# Altium schematic sheet Teensy Batt Board.SchDoc
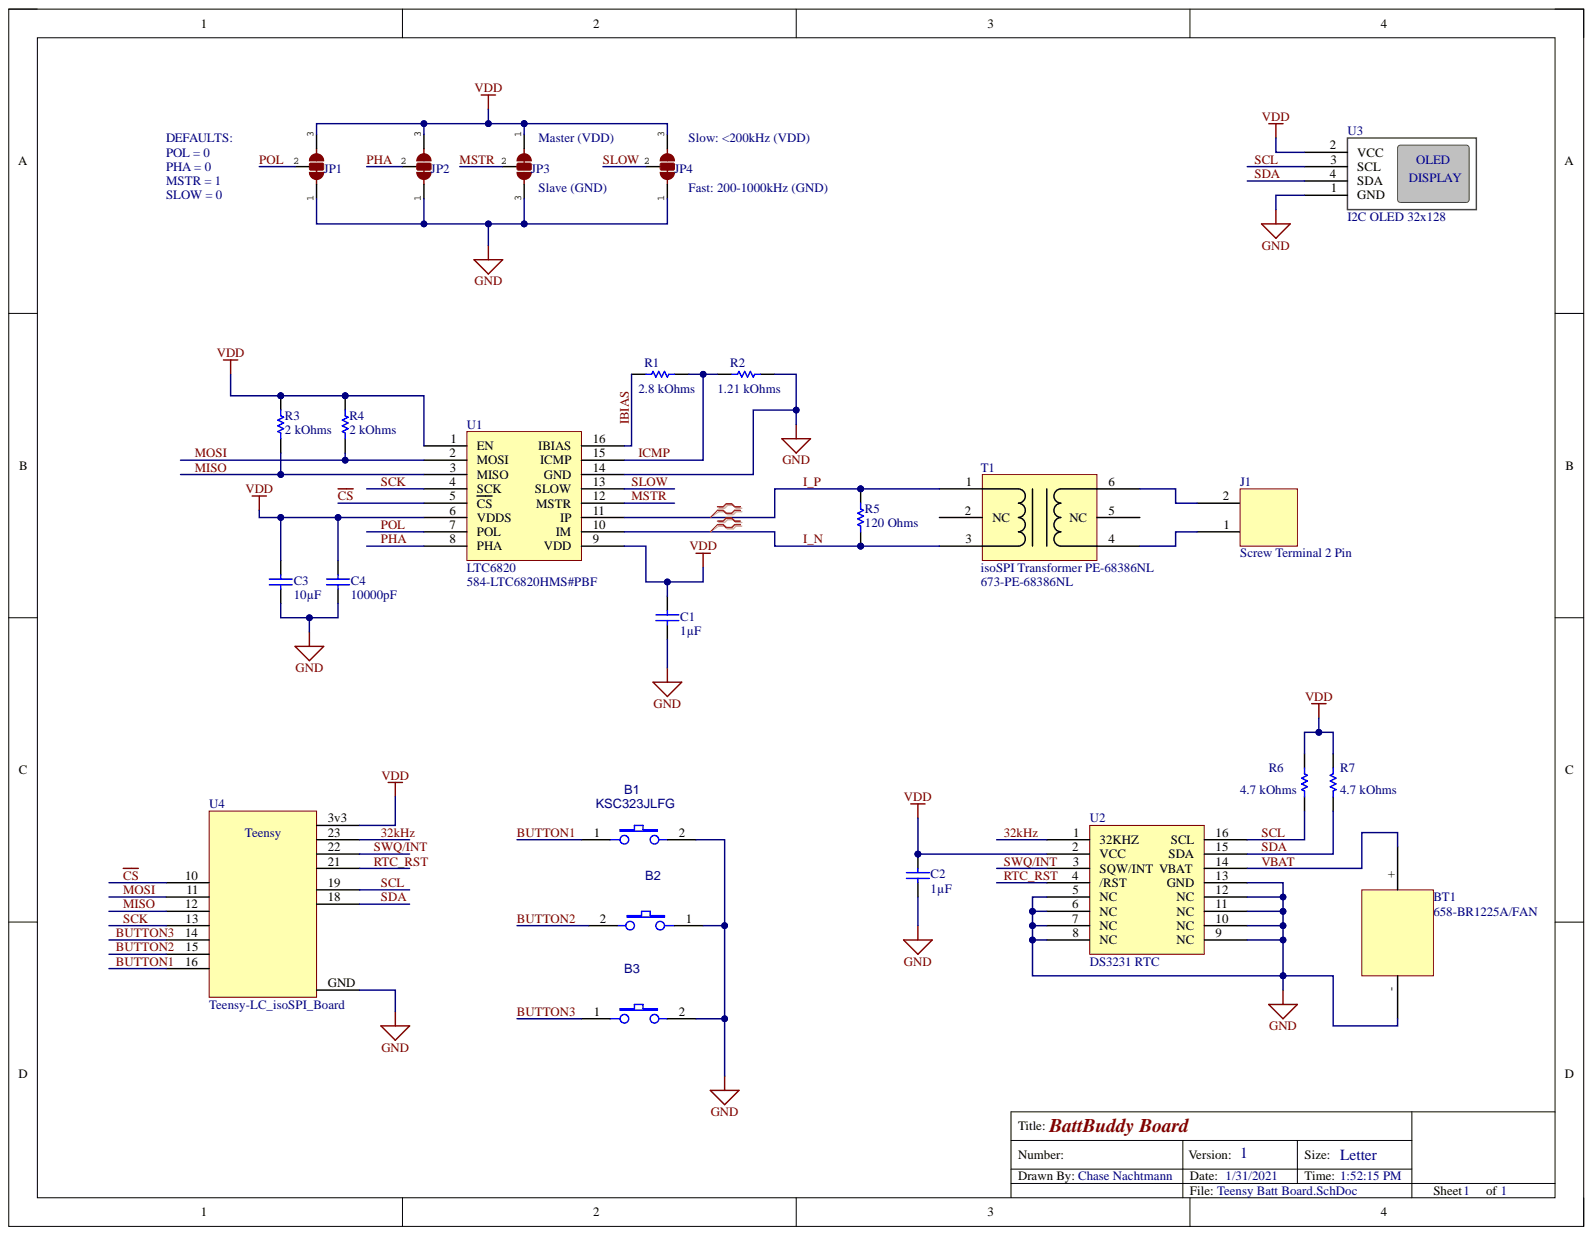
[diagram p0: Teensy Batt Board.SchDoc]

## Parts
B1  KSC323JLFG  [Pushbutton KSS223GLFG]
B2  KSC323JLFG  [Pushbutton KSS223GLFG]
B3  KSC323JLFG  [Pushbutton KSS223GLFG]
BT1  Supplier Part Number 1: 658-BR1225A/FAN  [Battery SMT Primary]  (hidden comment: Battery Primary)
C1  1µF  [CAPACITOR_0805]
C2  1µF  [CAPACITOR_0805]
C3  10µF  [CAPACITOR_0805]
C4  10000pF  [CAPACITOR_0805]
J1  Screw Terminal 2 Pin  [Screw Terminal 2Pos 5mm]
JP1  Jumper 2 Pad NC  [Jumper 3 Pad]  (value not drawn)
JP2  Jumper 2 Pad NC  [Jumper 3 Pad]  (value not drawn)
JP3  Jumper 2 Pad NC  [Jumper 3 Pad]  (value not drawn)
JP4  Jumper 2 Pad NC  [Jumper 3 Pad]  (value not drawn)
R1  value 2.8 kOhms  [RESISTOR_0603]  (hidden comment: Res1)
R2  value 1.21 kOhms  [RESISTOR_0603]  (hidden comment: Res1)
R3  value 2 kOhms  [RESISTOR_0603]  (hidden comment: Res1)
R4  value 2 kOhms  [RESISTOR_0603]  (hidden comment: Res1)
R5  value 120 Ohms  [RESISTOR_0603]  (hidden comment: Res1)
R6  value 4.7 kOhms  [RESISTOR_0603]  (hidden comment: Res1)
R7  value 4.7 kOhms  [RESISTOR_0603]  (hidden comment: Res1)
T1  isoSPI Transformer PE-68386NL  Supplier Part Number 1: 673-PE-68386NL  [PE-68386NL]
U1  LTC6820  Supplier Part Number 1: 584-LTC6820HMS#PBF  [LTC6820 MSOP16]
U2  DS3231 RTC  [DS3231 RTC SOIC127P1030X265-16N]
U3  I2C OLED 32x128  [OLED 32x128]
U4  Teensy-LC_isoSPI_Board  [Teensy-3.5_isoSPI_board]

## Nets
GND: B1.2 B2.1 B3.2 BT1.- C1.1 C2.1 C3.1 C4.1 JP1.1 JP2.1 JP3.3 JP4.1 R2.1 U1.14(GND) U2.5(NC) U2.6(NC) U2.7(NC) U2.8(NC) U2.9(NC) U2.10(NC) U2.11(NC) U2.12(NC) U2.13(GND) U3.1(GND) U4.GND
VDD: C1.2 C2.2 C3.2 C4.2 JP1.3 JP2.3 JP3.1 JP4.3 R3.2 R4.2 R6.2 R7.2 U1.1(EN) U1.6(VDDS) U1.9(VDD) U2.2(VCC) U3.2(VCC) U4.3v3
32kHz: U2.1(32KHZ) U4.23
BUTTON1: B1.1 U4.16
BUTTON2: B2.2 U4.15
BUTTON3: B3.1 U4.14
~{CS}: U1.5(CS) U4.10
I_N: R5.1 T1.3 U1.10(IM)
I_P: R5.2 T1.1 U1.11(IP)
IBIAS: R1.1 U1.16(IBIAS)
ICMP: R1.2 R2.2 U1.15(ICMP)
MISO: R3.1 U1.3(MISO) U4.12
MOSI: R4.1 U1.2(MOSI) U4.11
MSTR: JP3.2 U1.12(MSTR)
PHA: JP2.2 U1.8(PHA)
POL: JP1.2 U1.7(POL)
RTC_RST: U2.4(/RST) U4.21
SCK: U1.4(SCK) U4.13
SCL: R6.1 U2.16(SCL) U3.3(SCL) U4.19
SDA: R7.1 U2.15(SDA) U3.4(SDA) U4.18
SLOW: JP4.2 U1.13(SLOW)
SWQ/INT: U2.3(SQW/INT) U4.22
VBAT: BT1.+ U2.14(VBAT)
NetJ1_1: J1.1 T1.4
NetJ1_2: J1.2 T1.6

Not connected: T1 2(NC) 5(NC)

## Library data of the parts
B1-B3 = SW-PUSH-KSS223GLFG: SWITCH TACTILE SPST-NO 0.01A 50V; Manufacturer: ITT C&K; Actuator Length: 0.8mm; Contact Current Rating: 10mA; Illumination Type: Non-Illuminated; Max Voltage Rating (DC): 32V; Mechanical Life: 100000Cycles; Operating Force: 2N; Power Rating: 200mW; Ratings: IP40; Switch Function: Off-Mom; Switch Travel: 0.3mm; Throw Configuration: SPST; Case/Package: SMD/SMT; Category: Tactile Switches; Component Kind: Standard; ComponentLink1Description: Datasheet; ComponentLink1URL: https://www.mouser.com/datasheet/2/60/kss-1841788.pdf; ComponentLink2Description: https://datasheet.ciiva.com/2901/kss-2901088.pdf?src-supplier=Digi-Key; ComponentLink2URL: https://datasheet.ciiva.com/2901/kss-2901088.pdf?src-supplier=Digi-Key; ComponentLink3Description: https://datasheet.ciiva.com/2901/kss-2901089.pdf?src-supplier=Digi-Key; ComponentLink3URL: https://datasheet.ciiva.com/2901/kss-2901089.pdf?src-supplier=Digi-Key; ComponentLink4Description: https://datasheet.ciiva.com/2901/kss-2901090.pdf?src-supplier=Digi-Key; ComponentLink4URL: https://datasheet.ciiva.com/2901/kss-2901090.pdf?src-supplier=Digi-Key; ComponentLink5Description: https://datasheet.ciiva.com/16496/kss-16496167.pdf?src-supplier=Future+Electronics; ComponentLink5URL: https://datasheet.ciiva.com/16496/kss-16496167.pdf?src-supplier=Future+Electronics; Contact Material: Gold; Contact Plating: Gold; Current Rating: 10mA; Depth: 4.4mm; Lead Free: Lead Free; Length: 7mm; Manufacturer Part Number: KSS223GLFG; Max Current Rating: 10mA; Max Operating Temperature: 125°C; Min Operating Temperature: -40°C; Mount: Surface Mount; Orientation: Right Angle; Package Quantity: 3000; Packaging: Tape and Reel; Pricing 1: 1=0.77000, 10=0.73700, 50=0.68800, 100=0.61600, 1000=0.44200, 10000=0.44200 (USD); Radiation Hardening: No; RoHS: Yes; RoHS Compliant: Yes; Series: KSS; Stock 1: 2441; Supplier 1: Mouser; Supplier Part Number 1: 611-KSS223GLFG; Termination: Gull Wing; Voltage Rating (DC): 32V; Width: 3.5mm; Library Name: cnachtmann.SchLib
BT1 = Battery Primary: BATTERY LITHIUM 3V COIN 12.5MM; Manufacturer: Panasonic BSG; Manufacturer Part Number: BR1225A/FAN; Capacity: 0.048ah; Number of Cells: 1; Battery Type: PRIMARY; Category: Connectors; Chemistry/Technology: Lithium; Component Kind: Standard; ComponentLink1Description: http://industrial.panasonic.com/www-data/pdf2/AAA4000/AAA4000CE35.pdf?src-supplier=Avnet+Asia; ComponentLink1URL: http://industrial.panasonic.com/www-data/pdf2/AAA4000/AAA4000CE35.pdf?src-supplier=Avnet+Asia; ComponentLink2Description: http://industrial.panasonic.com/www-data/pdf2/AAA4000/AAA4000CE35.pdf?src-supplier=Avnet; ComponentLink2URL: http://industrial.panasonic.com/www-data/pdf2/AAA4000/AAA4000CE35.pdf?src-supplier=Avnet; Contact Plating: Tin; Height: 2.5mm; Max Operating Temperature: 125°C; Min Operating Temperature: -40°C; Mount: Through Hole; Pricing 1: 1=1.87000, 10=1.56000, 50=1.37000, 100=1.30000, 1000=1.09000, 10000=1.00000 (USD); RoHS: Yes; RoHS Compliant: Yes; Stock 1: 7371; Supplier 1: Mouser; Voltage: 3V; Voltage Rating: 3V; Library Name: cnachtmann.SchLib
C1 C2 = C0805C105K8RACTU: CAP CER 1UF 10V X7R 0805; Manufacturer: KEMET; Component: Standard; Database Table Name: Kemet_0805_Capacitors; Tolerance: ±10%; Applications: General Purpose; Component Kind: Standard; ComponentLink1Description: Datasheet; ComponentLink1URL: https://api.kemet.com/component-edge/download/datasheet/C0805C105K8RACTU.pdf; Digi-Key Part Number: 399-1172-1-ND; Features: Low ESL; Library Name: part_db.DbLib; Manufacturer Part Number: C0805C105K8RACTU; Mounting Type: Surface Mount, MLCC; Operating Temperature: -55°C ~ 125°C; Package / Case: 0805 (2012 Metric); Part Number: C0805C105K8RACTU; Ratings: -; Series: C; Supplier: Digi-Key; Temperature Coefficient: X7R; Value: 1µF; Voltage - Rated: 10V
C3 = C0805X106M9RAC7800: CAP CER 10UF 6.3V X7R 0805; Manufacturer: KEMET; Component: Standard; Database Table Name: Kemet_0805_Capacitors; Tolerance: ±20%; Applications: Boardflex Sensitive; Component Kind: Standard; ComponentLink1Description: Datasheet; ComponentLink1URL: https://api.kemet.com/component-edge/download/datasheet/C0805X106M9RAC7800.pdf; Digi-Key Part Number: 399-15700-1-ND; Features: Soft Termination; Library Name: part_db.DbLib; Manufacturer Part Number: C0805X106M9RAC7800; Mounting Type: Surface Mount, MLCC; Operating Temperature: -55°C ~ 125°C; Package / Case: 0805 (2012 Metric); Part Number: C0805X106M9RAC7800; Ratings: -; Series: FT-CAP; Supplier: Digi-Key; Temperature Coefficient: X7R; Value: 10µF; Voltage - Rated: 6.3V
C4 = C0805C103K8RAC7800: CAP CER 10000PF 10V X7R 0805; Manufacturer: KEMET; Component: Standard; Database Table Name: Kemet_0805_Capacitors; Tolerance: ±10%; Applications: General Purpose; Component Kind: Standard; ComponentLink1Description: Datasheet; ComponentLink1URL: https://api.kemet.com/component-edge/download/datasheet/C0805C103K8RACTU.pdf; Digi-Key Part Number: 399-14549-1-ND; Features: Low ESL; Library Name: part_db.DbLib; Manufacturer Part Number: C0805C103K8RAC7800; Mounting Type: Surface Mount, MLCC; Operating Temperature: -55°C ~ 125°C; Package / Case: 0805 (2012 Metric); Part Number: C0805C103K8RAC7800; Ratings: -; Series: C; Supplier: Digi-Key; Temperature Coefficient: X7R; Value: 10000pF; Voltage - Rated: 10V
JP1-JP4 = Jumper 3 Pad: 3 Pad Jumper Connection (P1/2 Normally Connected by Trace); Component Kind: Net Tie; Library Name: cnachtmann.SchLib
R1 = ERA-3AEB2801V: RES SMD 2.8K OHM 0.1% 1/10W 0603; Manufacturer: Panasonic Electronic Components; Component: Standard; Database Table Name: Panasonic_AEC-Q200_0603_Resistors; Power (Watts): 0.1W, 1/10W; Temperature Coefficient: ±25ppm/°C; Component Kind: Standard; ComponentLink1Description: Datasheet; ComponentLink1URL: https://industrial.panasonic.com/cdbs/www-data/pdf/RDM0000/AOA0000C307.pdf; Composition: Thin Film; Digi-Key Part Number: P2.8KDBCT-ND; Features: Automotive AEC-Q200; Library Name: part_db.DbLib; Manufacturer Part Number: ERA-3AEB2801V; Operating Temperature: -55°C ~ 155°C; Package / Case: 0603 (1608 Metric); Part Number: ERA-3AEB2801V; Series: ERA-3A; Supplier: Digi-Key; Supplier Device Package: 603; Tolerance: ±0.1%
R2 = ERA-3AEB1211V: RES SMD 1.21KOHM 0.1% 1/10W 0603; Manufacturer: Panasonic Electronic Components; Component: Standard; Database Table Name: Panasonic_AEC-Q200_0603_Resistors; Power (Watts): 0.1W, 1/10W; Temperature Coefficient: ±25ppm/°C; Component Kind: Standard; ComponentLink1Description: Datasheet; ComponentLink1URL: https://industrial.panasonic.com/cdbs/www-data/pdf/RDM0000/AOA0000C307.pdf; Composition: Thin Film; Digi-Key Part Number: P1.21KDBCT-ND; Features: Automotive AEC-Q200; Library Name: part_db.DbLib; Manufacturer Part Number: ERA-3AEB1211V; Operating Temperature: -55°C ~ 155°C; Package / Case: 0603 (1608 Metric); Part Number: ERA-3AEB1211V; Series: ERA-3A; Supplier: Digi-Key; Supplier Device Package: 603; Tolerance: ±0.1%
R3 R4 = ERA-3AEB202V: RES SMD 2K OHM 0.1% 1/10W 0603; Manufacturer: Panasonic Electronic Components; Component: Standard; Database Table Name: Panasonic_AEC-Q200_0603_Resistors; Power (Watts): 0.1W, 1/10W; Temperature Coefficient: ±25ppm/°C; Component Kind: Standard; ComponentLink1Description: Datasheet; ComponentLink1URL: https://industrial.panasonic.com/cdbs/www-data/pdf/RDM0000/AOA0000C307.pdf; Composition: Thin Film; Digi-Key Part Number: P2.0KDBCT-ND; Features: Automotive AEC-Q200; Library Name: part_db.DbLib; Manufacturer Part Number: ERA-3AEB202V; Operating Temperature: -55°C ~ 155°C; Package / Case: 0603 (1608 Metric); Part Number: ERA-3AEB202V; Series: ERA-3A; Supplier: Digi-Key; Supplier Device Package: 603; Tolerance: ±0.1%
R5 = ERA-3AEB121V: RES SMD 120 OHM 0.1% 1/10W 0603; Manufacturer: Panasonic Electronic Components; Component: Standard; Database Table Name: Panasonic_AEC-Q200_0603_Resistors; Power (Watts): 0.1W, 1/10W; Temperature Coefficient: ±25ppm/°C; Component Kind: Standard; ComponentLink1Description: Datasheet; ComponentLink1URL: https://industrial.panasonic.com/cdbs/www-data/pdf/RDM0000/AOA0000C307.pdf; Composition: Thin Film; Digi-Key Part Number: P120DBCT-ND; Features: Automotive AEC-Q200; Library Name: part_db.DbLib; Manufacturer Part Number: ERA-3AEB121V; Operating Temperature: -55°C ~ 155°C; Package / Case: 0603 (1608 Metric); Part Number: ERA-3AEB121V; Series: ERA-3A; Supplier: Digi-Key; Supplier Device Package: 603; Tolerance: ±0.1%
R6 R7 = ERJ-3EKF4701V: RES SMD 4.7K OHM 1% 1/10W 0603; Manufacturer: Panasonic Electronic Components; Component: Standard; Database Table Name: Panasonic_AEC-Q200_0603_Resistors; Power (Watts): 0.1W, 1/10W; Temperature Coefficient: ±100ppm/°C; Component Kind: Standard; ComponentLink1Description: Datasheet; ComponentLink1URL: https://industrial.panasonic.com/cdbs/www-data/pdf/RDA0000/AOA0000C304.pdf; Composition: Thick Film; Digi-Key Part Number: P4.70KHCT-ND; Features: Automotive AEC-Q200; Library Name: part_db.DbLib; Manufacturer Part Number: ERJ-3EKF4701V; Operating Temperature: -55°C ~ 155°C; Package / Case: 0603 (1608 Metric); Part Number: ERJ-3EKF4701V; Series: ERJ; Supplier: Digi-Key; Supplier Device Package: 603; Tolerance: ±1%
T1 = isoSPI Transformer PE-68386NL: Pulse Transformer 1:1 1500VDC 0.6Ohm Prim. DCR 0.6Ohm Sec. DCR 9.7Vus Surface Mount; DC Resistance (DCR): 600mR; Inductance: 785uH; Isolation Voltage: 1.5kV; Shielding: Unshielded; Category: Transformers; Component Kind: Standard; ComponentLink1Description: Datasheet; ComponentLink1URL: https://www.mouser.com/datasheet/2/336/SPM2007_61-1526932.pdf; ComponentLink2Description: https://datasheet.ciiva.com/2939/+spm2007-61-2939892.pdf?src-supplier=Digi-Key; ComponentLink2URL: https://datasheet.ciiva.com/2939/+spm2007-61-2939892.pdf?src-supplier=Digi-Key; Depth: 8.64mm; Height: 2.5mm; Length: 8.6mm; Manufacturer: Pulse; Manufacturer Part Number: PE-68386NL; Max Operating Temperature: 130°C; Min Operating Temperature: -40°C; Mount: Surface Mount; Number of Pins: 6; Package Quantity: 85; Packaging: Rail/Tube; Pricing 1: 1=2.10000, 10=1.87000, 50=1.33000, 100=1.28000, 1000=1.17000, 10000=1.13000 (USD); Radiation Hardening: No; RoHS: Yes; Stock 1: 1643; Supplier 1: Mouser; Termination: SMD/SMT; Turns Ratio: 1:1; Width: 6.7mm; Library Name: cnachtmann.SchLib
U1 = LTC6820: Isolated Communications Interface Automotive 16-Pin MSOP; Manufacturer: Analog Devices / Linear Technology; Manufacturer Part Number: LTC6820HMS#PBF; Interface: SPI; Max Supply Voltage: 5.5V; Min Supply Voltage: 2.7V; Case/Package: MSOP; Category: Interface ICs; Component Kind: Standard; ComponentLink1Description: https://datasheet.ciiva.com/22649/1730562-22649441.pdf?src-supplier=Element14; ComponentLink1URL: https://datasheet.ciiva.com/22649/1730562-22649441.pdf?src-supplier=Element14; ComponentLink2Description: https://datasheet.ciiva.com/6573/42317-6573703.pdf?src-supplier=Digi-Key; ComponentLink2URL: https://datasheet.ciiva.com/6573/42317-6573703.pdf?src-supplier=Digi-Key; ComponentLink3Description: https://datasheet.ciiva.com/22767/1730562-22767675.pdf?src-supplier=Farnell; ComponentLink3URL: https://datasheet.ciiva.com/22767/1730562-22767675.pdf?src-supplier=Farnell; Max Operating Temperature: 125°C; Min Operating Temperature: -40°C; Number of Pins: 16; Package Quantity: 37; Packaging: Rail/Tube; REACH SVHC: No SVHC; RoHS: Yes; RoHS Compliant: Yes; Series: isoSPI; Supplier 1: Mouser; Library Name: cnachtmann.SchLib
U3 = I2C OLED 32x128: I2C OLED MODULE; Component Kind: Standard; Library Name: cnachtmann.SchLib
J1 = Screw Terminal 2 Pin: Component Kind: Standard; Library Name: cnachtmann.SchLib
U2 = DS3231 RTC: Component Kind: Standard; Library Name: cnachtmann.SchLib
U4 = Teensy-LC_isoSPI_Board: Component Kind: Standard; Library Name: cnachtmann.SchLib
